# Altium schematic sheet Radio.SchDoc
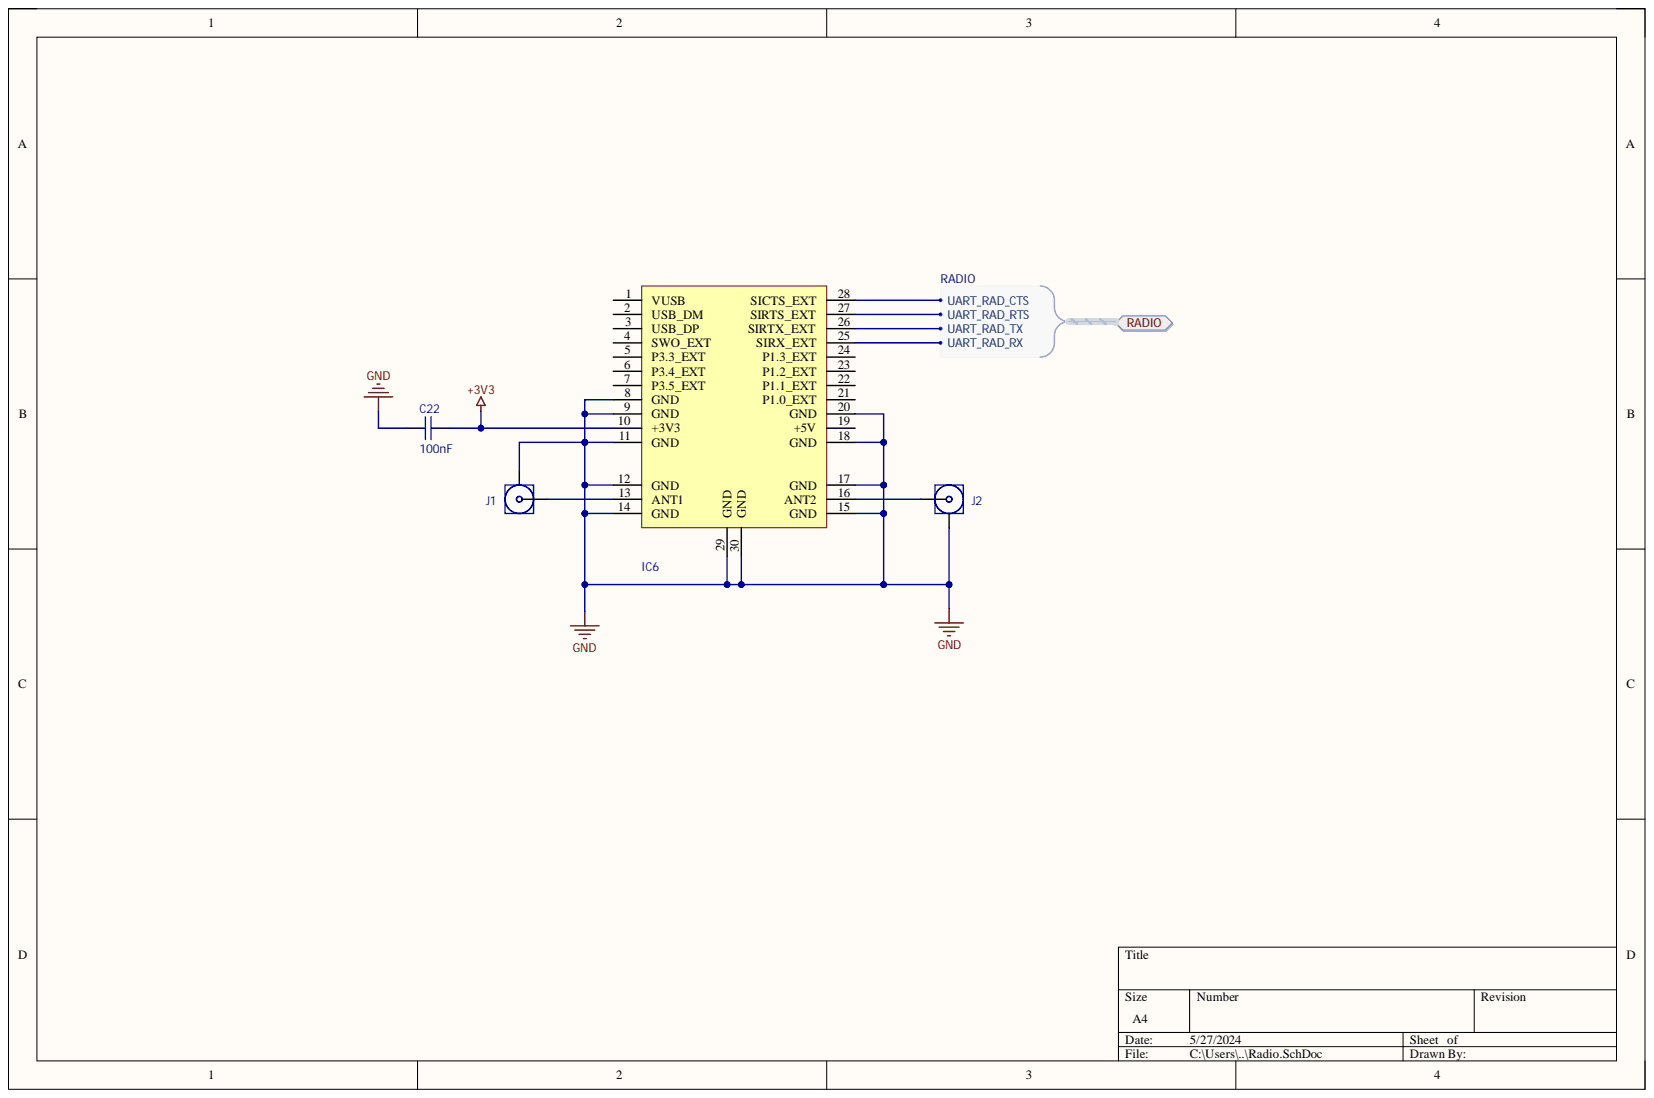
[diagram p0: Radio.SchDoc]

## Parts
C22  value 100nF  Capacitance: 100nF  [CAPC1608X10N]  (hidden comment: C0603C104M5RACTU)
IC6  RFD900ux  [RFD900ux-Footprint-1]  (value not drawn)
J1  PCB.SMAFST.J.A.HT  [PCB.SMAFST.J.A.HT-Footprint-1]  (value not drawn)
J2  PCB.SMAFST.J.A.HT  [PCB.SMAFST.J.A.HT-Footprint-1]  (value not drawn)

## Ports
RADIO, RADIO.UART_RAD_CTS, RADIO.UART_RAD_RTS, RADIO.UART_RAD_RX, RADIO.UART_RAD_TX

## Nets
+3V3: C22.2 IC6.10(+3V3)
GND: C22.1 IC6.8(GND) IC6.9(GND) IC6.11(GND) IC6.12(GND) IC6.14(GND) IC6.15(GND) IC6.17(GND) IC6.18(GND) IC6.20(GND) IC6.29(GND) IC6.30(GND) J1.2 J2.2
NetIC6_1: IC6.1(VUSB)
NetIC6_2: IC6.2(USB_DM)
NetIC6_3: IC6.3(USB_DP)
NetIC6_4: IC6.4(SWO_EXT)
NetIC6_5: IC6.5(P3.3_EXT)
NetIC6_6: IC6.6(P3.4_EXT)
NetIC6_7: IC6.7(P3.5_EXT)
NetIC6_13: IC6.13(ANT1) J1.1
NetIC6_16: IC6.16(ANT2) J2.1
NetIC6_19: IC6.19(+5V)
NetIC6_21: IC6.21(P1.0_EXT)
NetIC6_22: IC6.22(P1.1_EXT)
NetIC6_23: IC6.23(P1.2_EXT)
NetIC6_24: IC6.24(P1.3_EXT)
NetIC6_25: IC6.25(SIRX_EXT) ; port RADIO
NetIC6_26: IC6.26(SIRTX_EXT) ; port RADIO
NetIC6_27: IC6.27(SIRTS_EXT) ; port RADIO
NetIC6_28: IC6.28(SICTS_EXT) ; port RADIO

## Library data of the parts
C22 = CMP-00038-5: Cap Ceramic 0.1uF 50V X7R 20% SMD 0603 125C T/R; Dissipation Factor: 2.5%; Case Code (Imperial): 0603; Case Code (Metric): 1608; Case/Package: 0603; Component Kind: Standard; Contact Plating: Tin,Matte; Depth: 0.8mm; Dielectric: X7R; Dielectric Material: Ceramic; Height: 0.8mm; JLC_PN: C14663; Lead Pitch: 0.7mm; Length: 1.6mm; Max Operating Temperature: 125°C; Min Operating Temperature: -55°C; Mount: Surface Mount,PCB; Package Quantity: 1; Packaging: Tape and Reel; Radiation Hardening: No; REACH SVHC: No SVHC; Termination: SMD/SMT; Thickness: 0.034inch; Tolerance: 20%; Voltage Rating: 50V; Voltage Rating (DC): 50V; Width: 0.031inch; Library Name: UWA Aerospace
IC6 = CMP-00019-3: Long range surface mount radio module 902-928Mhz; Component Kind: Standard; Library Name: UWA Aerospace
J1 J2 = CMP-00234-3: CONN SMA JACK STR 50OHM PCB; Body Material: Brass; Body Plating: Gold; Dielectric Material: PTFE; Frequency: 12.4GHz; Impedance: 50R; Insulation Resistance: 5GR; Operating Frequency: 0 to 12.4 GHz; Polarity: Standard; Voltage Rating: 335V; Case/Package: SMA; Center Contact Plating: Gold; Component Kind: Standard; Connector Type: SMA; Contact Material: Bronze; Contact Plating: Gold; Fastening Type: Threaded; Housing Color: Gold; Max Frequency: 12.4GHz; Mount: Surface Mount; Orientation: Straight; Package Quantity: 500; Packaging: Tray; Plating: Gold; Series: -; Termination: Solder; Library Name: UWA Aerospace
